# Altium schematic sheet pyAI-K210.SchDoc(pyAI-K210)
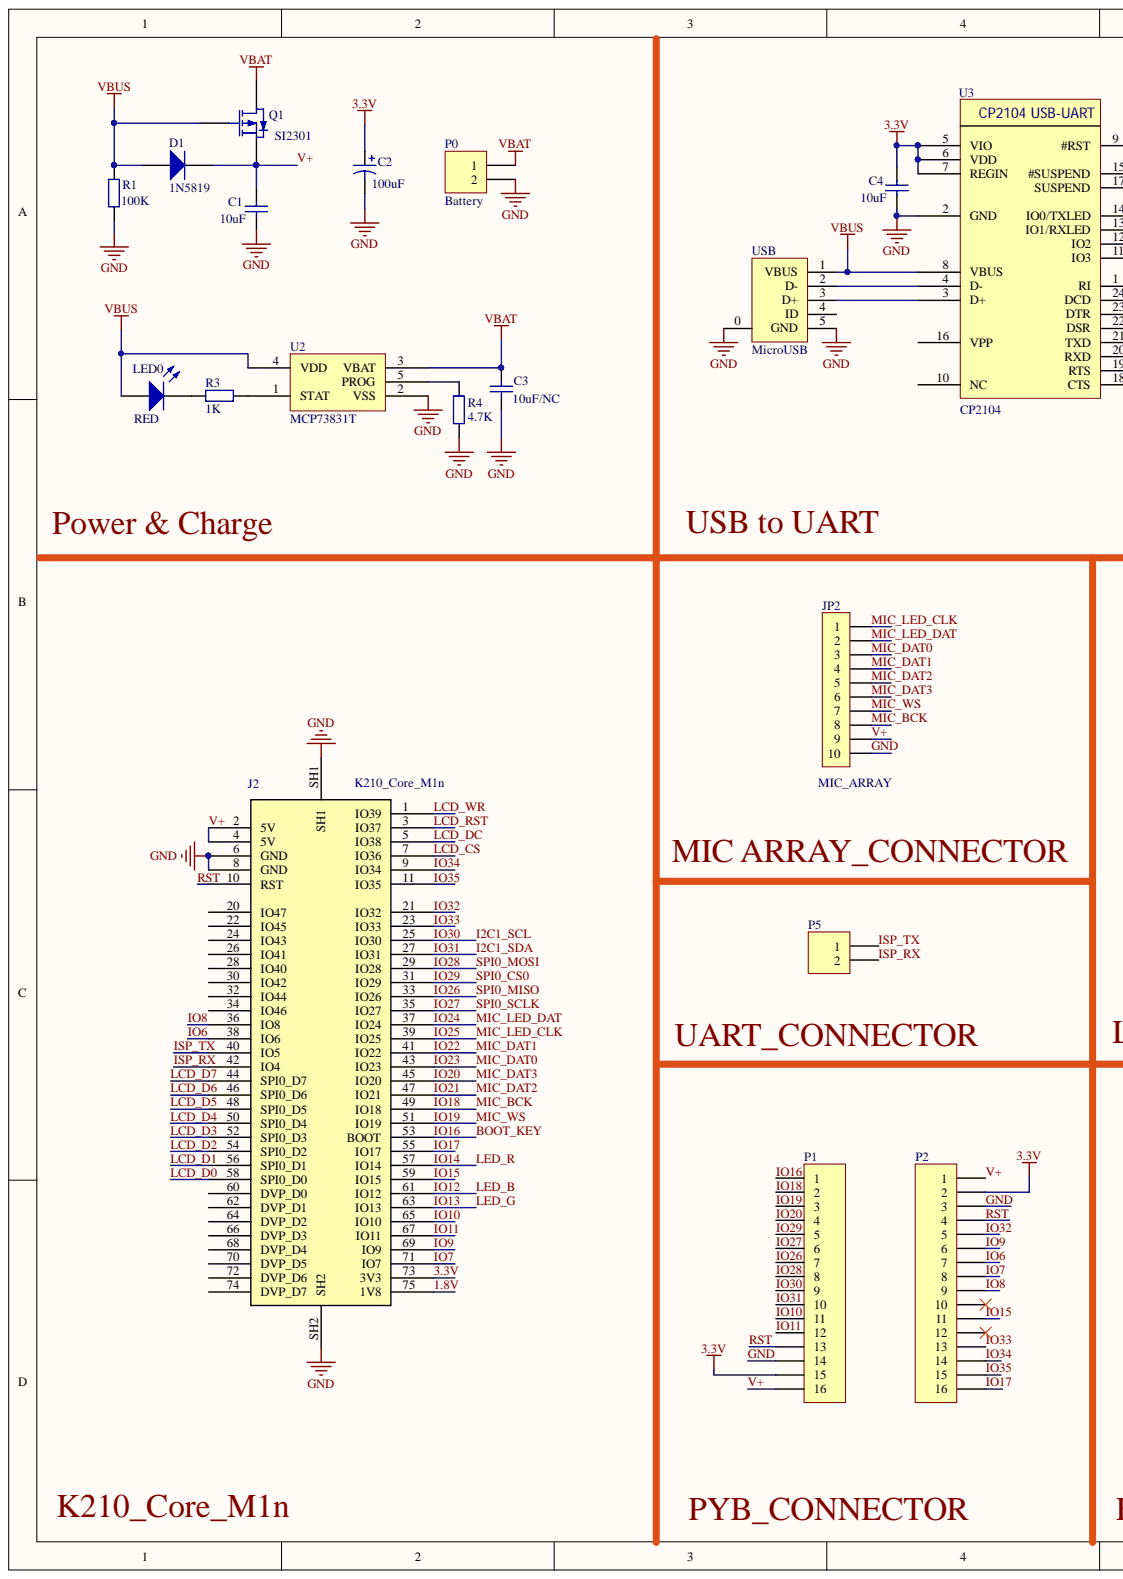
[diagram p0: pyAI-K210.SchDoc, left]
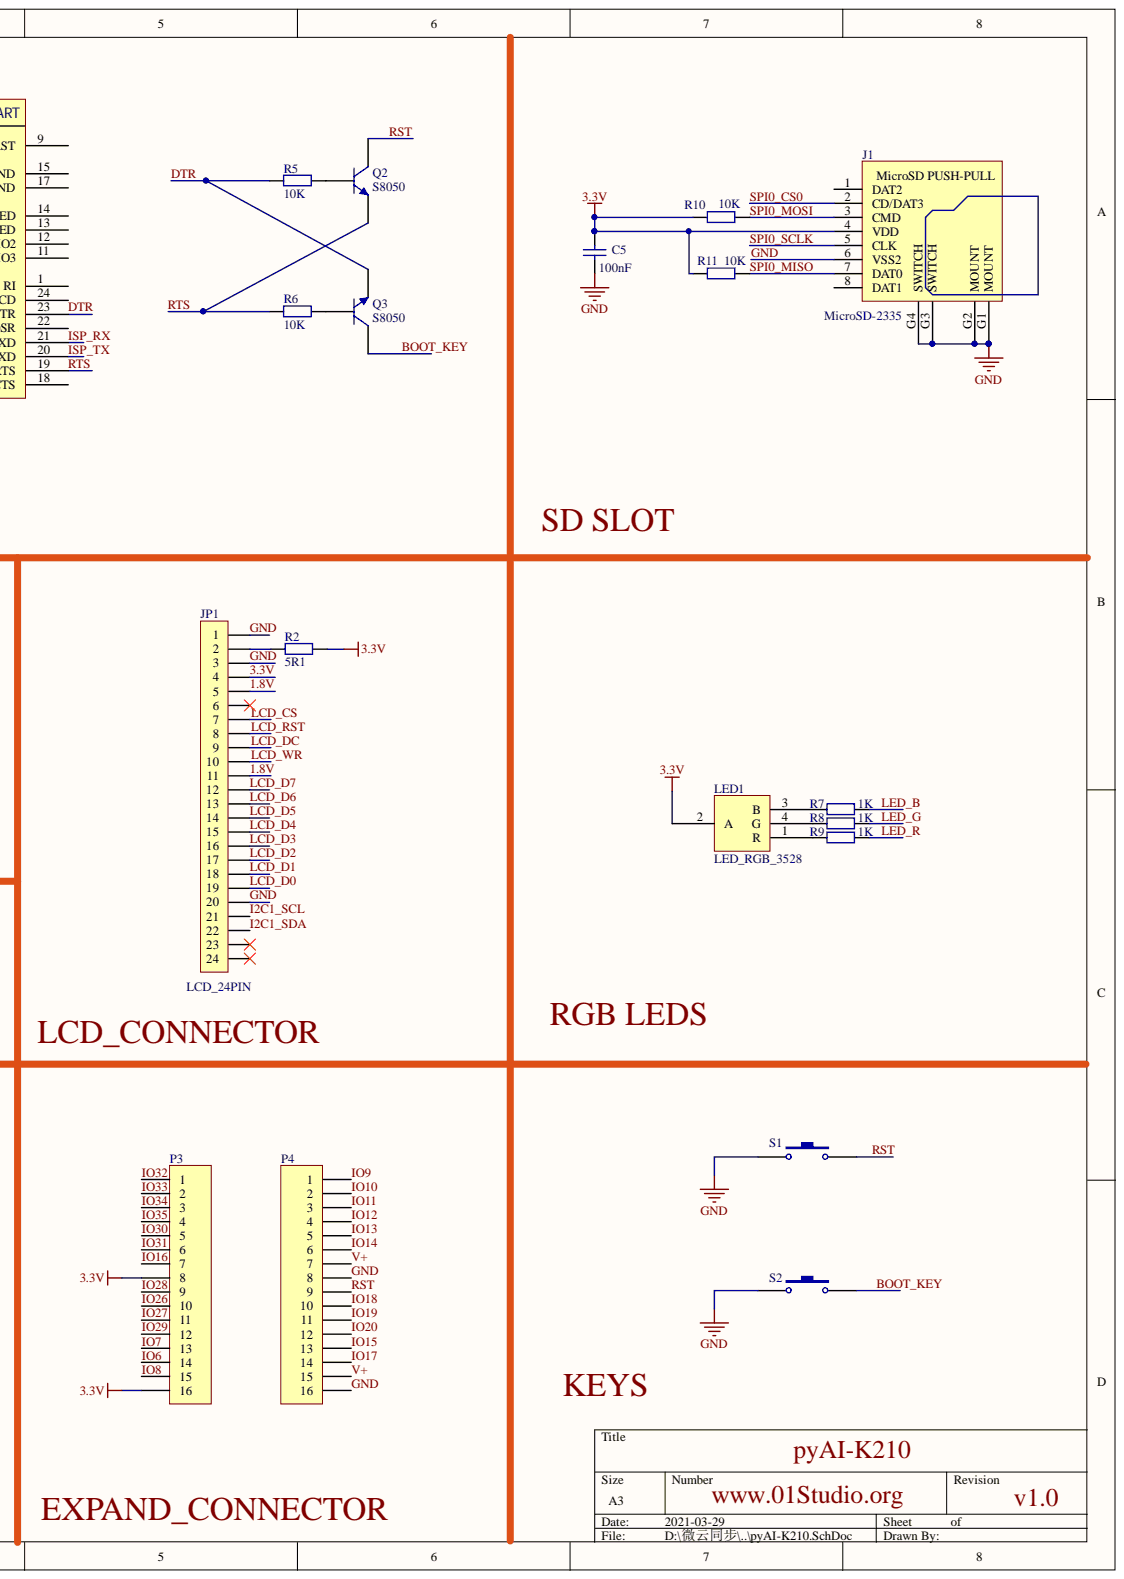
[diagram p1: pyAI-K210.SchDoc, right]

## Parts
C1  value 10uF  [C0402]
C2  value 100uF  [B型钽电容]
C3  value 10uF/NC  [C0402]
C4  value 10uF  [C0402]
C5  100nF  [C0402]
D1  1N5819  [SOD-323]
J1  MicroSD-2335  [MicroSD卡座-2335]
J2  K210_Core_M1n  [M2-67P0R50_B_FEMALE]
JP1  LCD_24PIN  [FPC_24P_UP&DOWN]
JP2  MIC_ARRAY  [FPC_10P_UP&DOWN]
LED0  RED  [D0603]
LED1  LED_RGB_3528  [LED_RGB_3528]
P0  Battery  [XH2.54-2P/卧贴]
P1  (no value)  [1*16P/2.54]
P2  (no value)  [1*16P/2.54]
P3  (no value)  [1*16P/2.54]
P4  (no value)  [1*16P/2.54]
P5  (no value)  [HDR1X2]
Q1  SI2301  [SOT-23]
Q2  S8050  [SOT-23]
Q3  S8050  [SOT-23]
R1  value 100K  [R0402]
R2  value 5R1  [R0402]
R3  value 1K  [R0402]
R4  value 4.7K  [R0402]
R5  value 10K  [R0402]
R6  value 10K  [R0402]
R7  value 1K  [R0402]
R8  value 1K  [R0402]
R9  value 1K  [R0402]
R10  value 10K  [R0402]
R11  value 10K  [R0402]
S1  (no value)  [侧插按键开关 3*6*5]
S2  (no value)  [侧插按键开关 3*6*5]
U2  MCP73831T  [SOT23-5]
U3  CP2104  [QFN24]
USB  MicroUSB  [MicroUSB(母座前插后贴)]

## Nets
VBAT: C3.2 P0.1 Q1.3 U2.3(VBAT)
VBUS: D1.1 LED0.1 Q1.1 R1.1 U2.4(VDD) U3.8(VBUS) USB.1(VBUS)
1.8V: J2.75(1V8) JP1.5 JP1.11
3.3V: C2.1 C4.2 C5.2 J1.4(VDD) J2.73(3V3) JP1.4 LED1.2(A) P1.15 P2.2 P3.8 P3.16 R2.1 R10.2 R11.2 U3.5(VIO) U3.6(VDD) U3.7(REGIN)
BOOT_KEY: J2.53(BOOT) P1.1 P3.7 Q3.3 S2.2
DTR: Q3.2 R5.1 U3.23(DTR)
GND: C1.1 C2.2 C3.1 C4.1 C5.1 J1.6(VSS2) J1.G1(MOUNT) J1.G2(MOUNT) J1.G3(SWITCH) J1.G4(SWITCH) J2.6(GND) J2.8(GND) J2.SH1 J2.SH2 JP1.1 JP1.3 JP1.20 JP2.10 P0.2 P1.14 P2.3 P4.8 P4.16 R1.2 R4.1 S1.1 S2.1 U2.2(VSS) U3.2(GND) USB.0 USB.5(GND)
I2C1_SCL: J2.25(IO30) JP1.21 P1.9 P3.5
I2C1_SDA: J2.27(IO31) JP1.22 P1.10 P3.6
IO6: J2.38(IO6) P2.7 P3.14
IO7: J2.71(IO7) P2.8 P3.13
IO8: J2.36(IO8) P2.9 P3.15
IO9: J2.69(IO9) P2.6 P4.1
IO10: J2.65(IO10) P1.11 P4.2
IO11: J2.67(IO11) P1.12 P4.3
IO12: J2.61(IO12) P4.4 R7.2
IO13: J2.63(IO13) P4.5 R8.2
IO14: J2.57(IO14) P4.6 R9.2
IO15: J2.59(IO15) P2.11 P4.13
IO17: J2.55(IO17) P2.16 P4.14
IO18: J2.49(IO18) JP2.8 P1.2 P4.10
IO19: J2.51(IO19) JP2.7 P1.3 P4.11
IO20: J2.45(IO20) JP2.6 P1.4 P4.12
IO21: J2.47(IO21) JP2.5
IO22: J2.41(IO22) JP2.4
IO23: J2.43(IO23) JP2.3
IO24: J2.37(IO24) JP2.2
IO25: J2.39(IO25) JP2.1
IO26: J1.7(DAT0) J2.33(IO26) P1.7 P3.10 R11.1
IO27: J1.5(CLK) J2.35(IO27) P1.6 P3.11
IO28: J1.3(CMD) J2.29(IO28) P1.8 P3.9 R10.1
IO29: J1.2(CD/DAT3) J2.31(IO29) P1.5 P3.12
IO32: J2.21(IO32) P2.5 P3.1
IO33: J2.23(IO33) P2.13 P3.2
IO34: J2.9(IO34) P2.14 P3.3
IO35: J2.11(IO35) P2.15 P3.4
ISP_RX: J2.42(IO4) P5.2 U3.21(TXD)
ISP_TX: J2.40(IO5) P5.1 U3.20(RXD)
LCD_CS: J2.7(IO36) JP1.7
LCD_D0: J2.58(SPI0_D0) JP1.19
LCD_D1: J2.56(SPI0_D1) JP1.18
LCD_D2: J2.54(SPI0_D2) JP1.17
LCD_D3: J2.52(SPI0_D3) JP1.16
LCD_D4: J2.50(SPI0_D4) JP1.15
LCD_D5: J2.48(SPI0_D5) JP1.14
LCD_D6: J2.46(SPI0_D6) JP1.13
LCD_D7: J2.44(SPI0_D7) JP1.12
LCD_DC: J2.5(IO38) JP1.9
LCD_RST: J2.3(IO37) JP1.8
LCD_WR: J2.1(IO39) JP1.10
RST: J2.10(RST) P1.13 P2.4 P4.9 Q2.3 S1.2
RTS: Q2.2 R6.1 U3.19(RTS)
V+: C1.2 D1.2 J2.2(5V) J2.4(5V) JP2.9 P1.16 P2.1 P4.7 P4.15 Q1.2
NetJP1_2: JP1.2 R2.2
NetLED0_2: LED0.2 R3.1
NetLED1_1: LED1.1(R) R9.1
NetLED1_3: LED1.3(B) R7.1
NetLED1_4: LED1.4(G) R8.1
NetQ2_1: Q2.1 R5.2
NetQ3_1: Q3.1 R6.2
NetR3_2: R3.2 U2.1(STAT)
NetR4_2: R4.2 U2.5(PROG)
NetU3_3: U3.3(D+) USB.3(D+)
NetU3_4: U3.4(D-) USB.2(D-)

Not connected: J1 1(DAT2) 8(DAT1); J2 20(IO47) 22(IO45) 24(IO43) 26(IO41) 28(IO40) 30(IO42) 32(IO44) 34(IO46) 60(DVP_D0) 62(DVP_D1) 64(DVP_D2) 66(DVP_D3) 68(DVP_D4) 70(DVP_D5) 72(DVP_D6) 74(DVP_D7); JP1 6 23 24; P2 10 12; U3 1(RI) 9(#RST) 10(NC) 11(IO3) 12(IO2) 13(IO1/RXLED) 14(IO0/TXLED) 15(#SUSPEND) 16(VPP) 17(SUSPEND) 18(CTS) 22(DSR) 24(DCD); USB 4(ID)

## Library data of the parts
C1 C3 C4 = Cap: Capacitor; Simulation: CAP; Signal Integrity: Cap; Published: 8-Jun-2000; PackageReference: RAD-0.3; Library Name: Miscellaneous Devices.IntLib; LatestRevisionNote: Re-released for DXP Platform.; LatestRevisionDate: 17-Jul-2002; Component Kind: Standard; Publisher: Altium Limited
C2 = Cap Pol1: Polarized Capacitor (Radial); Simulation: CAP; Signal Integrity: Cap Pol; Published: 8-Jun-2000; PackageReference: RB7.6-15; Library Name: Miscellaneous Devices.IntLib; LatestRevisionNote: Re-released for DXP Platform.; LatestRevisionDate: 17-Jul-2002; Component Kind: Standard; Publisher: Altium Limited
C5 = Cap: Capacitor; Value: 100pF; Simulation: CAP; Signal Integrity: Cap; Published: 8-Jun-2000; PackageReference: RAD-0.3; Library Name: Miscellaneous Devices.IntLib; LatestRevisionNote: Re-released for DXP Platform.; LatestRevisionDate: 17-Jul-2002; Component Kind: Standard; Publisher: Altium Limited
D1 = Diode: Default Diode; Simulation: DIODE; Signal Integrity: Diode; Published: 8-Jun-2000; PackageReference: SMC; PackageDocument: Sep-1996; Library Name: Miscellaneous Devices.IntLib; LatestRevisionNote: Re-released for DXP Platform.; LatestRevisionDate: 17-Jul-2002; Component Kind: Standard; Code_JEDEC: DO-214-AB; Publisher: Altium Limited
J2 = NGFF-67P_B-MALE: Component Kind: Standard
JP1 = FPC-24P: FPC连接器; 版权归属: 源创客; 学习资源: www.ooocke.com; Library Name: 4.14 - FPC连接器.SchLib; Component Kind: Standard; BOM名称: FPC连接器
JP2 = FPC-10P: FPC连接器; 版权归属: 源创客; 学习资源: www.ooocke.com; Library Name: 4.14 - FPC连接器.SchLib; Component Kind: Standard; BOM名称: FPC连接器
LED0 = LED1: Typical RED GaAs LED; Simulation: LED1; Published: 8-Jun-2000; PackageReference: LED-1; Library Name: Miscellaneous Devices.IntLib; LatestRevisionNote: Mech Layer 1 removed.; LatestRevisionDate: 08-Jul-2005; Component Kind: Standard; Publisher: Altium Limited
P0 = MHDR1X2: Header, 2-Pin; Signal Integrity: Connector; Library Name: Miscellaneous Connectors.IntLib; LatestRevisionNote: Re-released for DXP Platform.; LatestRevisionDate: 17-Jul-2002; Component Kind: Standard; Publisher: Altium Limited
P1-P4 = Header 16: Header, 16-Pin; Signal Integrity: Connector; Library Name: Miscellaneous Connectors.IntLib; LatestRevisionNote: Re-released for DXP Platform.; LatestRevisionDate: 17-Jul-2002; Component Kind: Standard; Publisher: Altium Limited
P5 = Header 2: Header, 2-Pin; Signal Integrity: Connector; Library Name: Miscellaneous Connectors.IntLib; LatestRevisionNote: Re-released for DXP Platform.; LatestRevisionDate: 17-Jul-2002; Component Kind: Standard; Publisher: Altium Limited
Q1 = P-MOS: Library Name: WeBee.SCHLIB; Component Kind: Standard
R1-R11 = Res2: Resistor; Simulation: RESISTOR; Signal Integrity: Res; Published: 8-Jun-2000; PackageReference: AXIAL-0.4; Library Name: Miscellaneous Devices.IntLib; LatestRevisionNote: Re-released for DXP Platform.; LatestRevisionDate: 17-Jul-2002; Component Kind: Standard; Publisher: Altium Limited
S1 S2 = SW-PB: Switch; Published: 24-Mar-1999; PackageReference: SPST-2; Library Name: Miscellaneous Devices.IntLib; LatestRevisionNote: Re-released for DXP Platform.; LatestRevisionDate: 17-Jul-2002; Component Kind: Standard; Publisher: Altium Limited
J1 = MicroSD-2335: Library Name: WeBee.SCHLIB; Component Kind: Standard
LED1 = LED_RGB_3528: Component Kind: Standard
Q2 Q3 = S8050: Library Name: WeBee.SCHLIB; Component Kind: Standard
U2 = MCP73831T: Component Kind: Standard
U3 = CP2104: Component Kind: Standard
USB = MicroUSB: Library Name: WeBee.SCHLIB; Component Kind: Standard
